# Altium schematic sheet SC8701_EVM_RE1_0624.SchDoc(SC8701_EVM_RE1_0624)
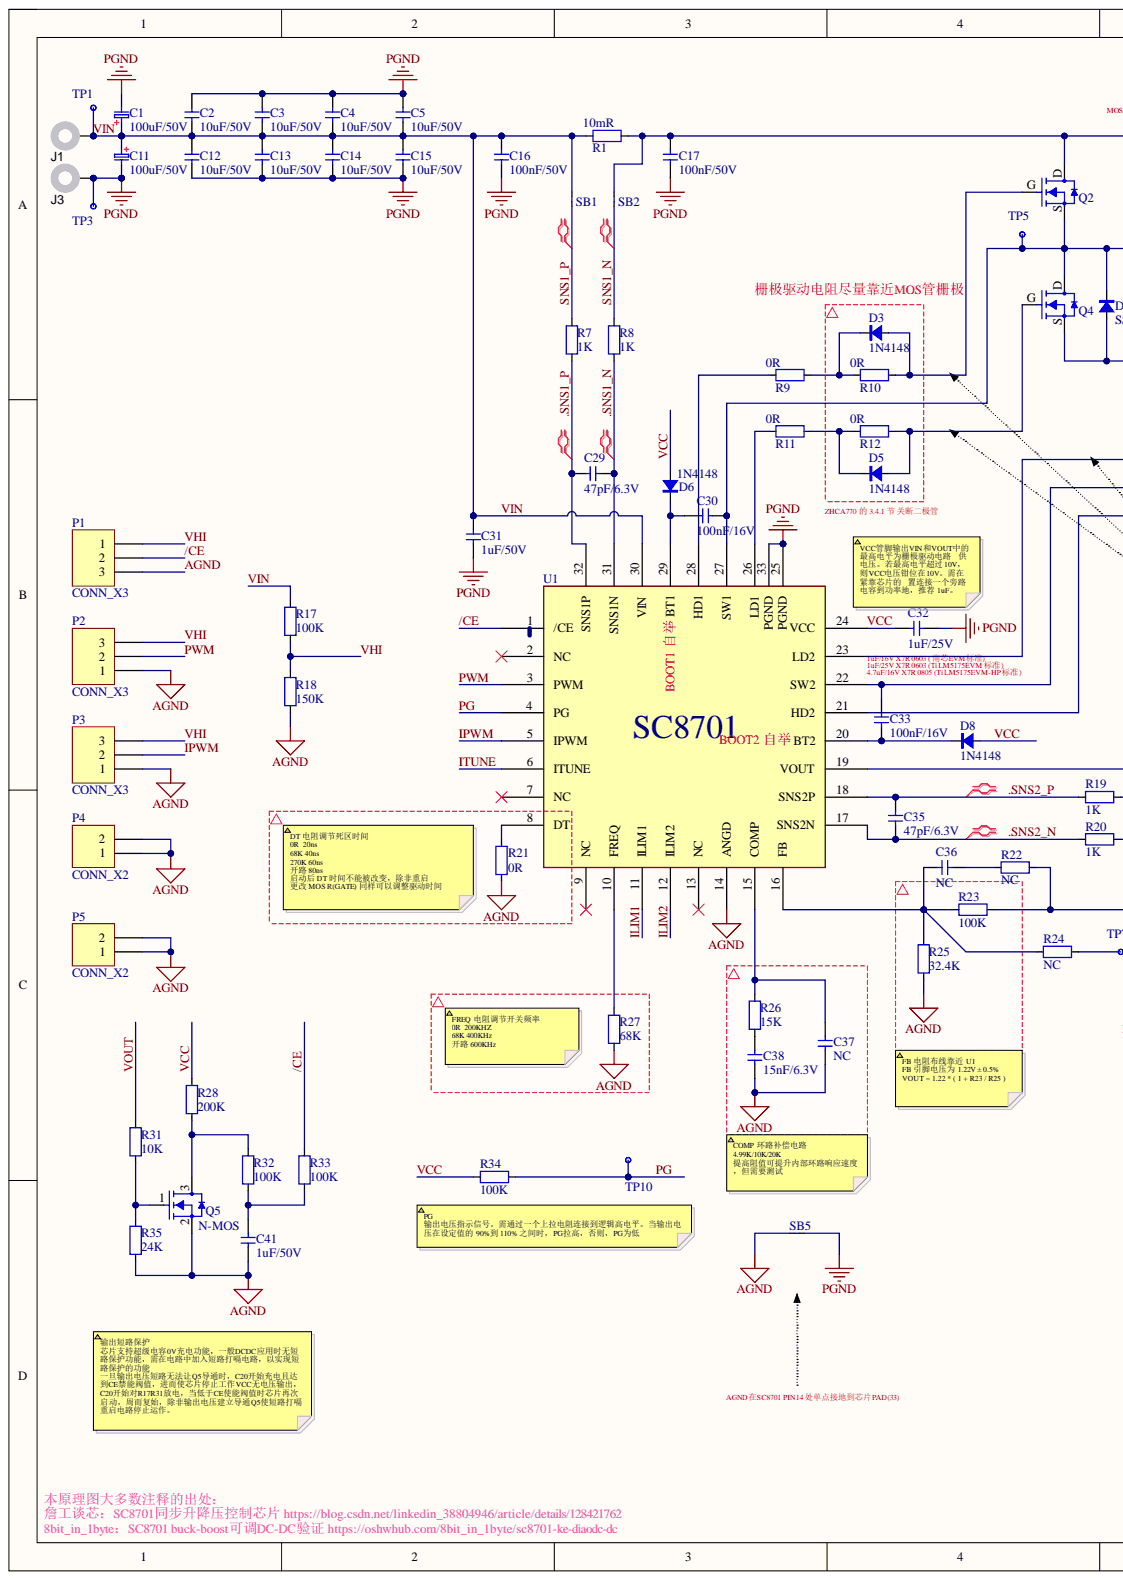
[diagram p0: SC8701_EVM_RE1_0624.SchDoc, left]
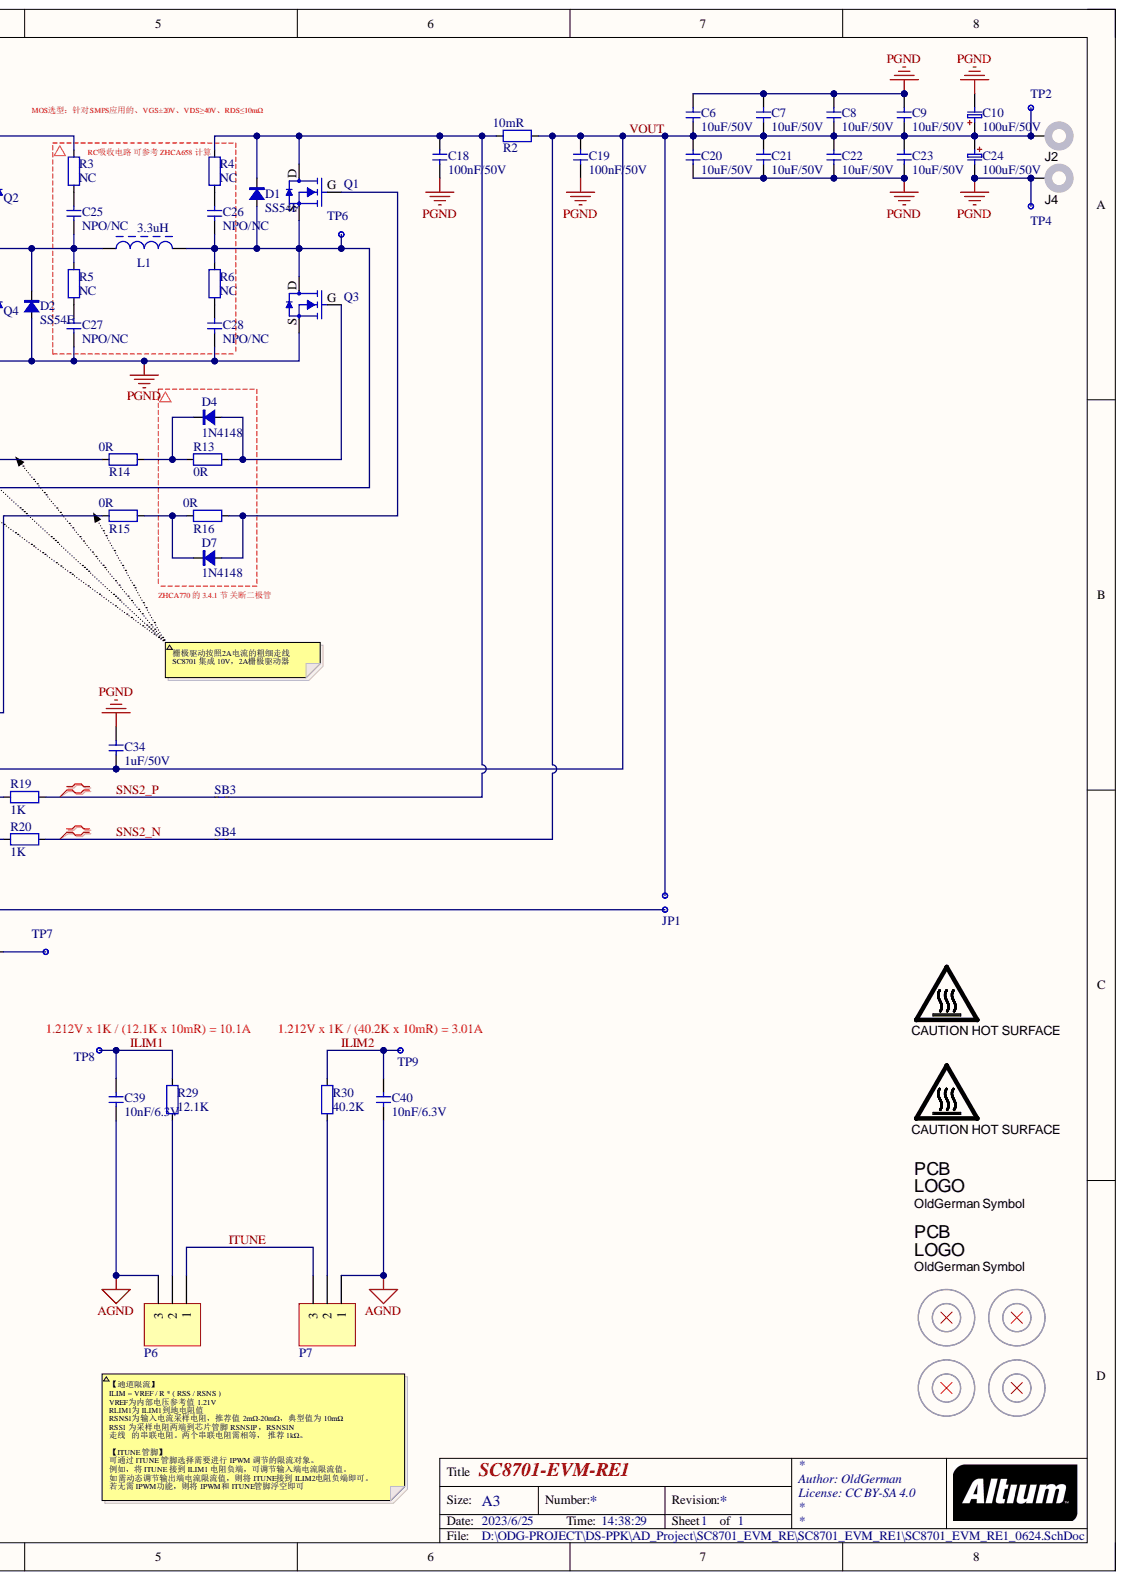
[diagram p1: SC8701_EVM_RE1_0624.SchDoc, right]

## Parts
C1  100uF/50V  [CAP OS-CON D=10.0 L=10.0 F10]
C2  10uF/50V  [C1210]
C3  10uF/50V  [C1210]
C4  10uF/50V  [C1210]
C5  10uF/50V  [C1210]
C6  10uF/50V  [C1210]
C7  10uF/50V  [C1210]
C8  10uF/50V  [C1210]
C9  10uF/50V  [C1210]
C10  100uF/50V  [CAP OS-CON D=10.0 L=10.0 F10]
C11  100uF/50V  [CAP OS-CON D=10.0 L=10.0 F10]
C12  10uF/50V  [C1210]
C13  10uF/50V  [C1210]
C14  10uF/50V  [C1210]
C15  10uF/50V  [C1210]
C16  100nF/50V  [C0603]
C17  100nF/50V  [C0603]
C18  100nF/50V  [C0603]
C19  100nF/50V  [C0603]
C20  10uF/50V  [C1210]
C21  10uF/50V  [C1210]
C22  10uF/50V  [C1210]
C23  10uF/50V  [C1210]
C24  100uF/50V  [CAP OS-CON D=10.0 L=10.0 F10]
C25  NPO/NC  [C0805]
C26  NPO/NC  [C0805]
C27  NPO/NC  [C0805]
C28  NPO/NC  [C0805]
C29  47pF/6.3V  [C0603]
C30  100nF/16V  [C0603]
C31  1uF/50V  [C0603]
C32  1uF/25V  [C0805]
C33  100nF/16V  [C0603]
C34  1uF/50V  [C0603]
C35  47pF/6.3V  [C0603]
C36  NC  [C0603]
C37  NC  [C0603]
C38  15nF/6.3V  [C0603]
C39  10nF/6.3V  [C0603]
C40  10nF/6.3V  [C0603]
C41  1uF/50V  [C0603]
D1  SS54F  [SMAF]
D2  SS54F  [SMAF]
D3  1N4148  [SOD-323]
D4  1N4148  [SOD-323]
D5  1N4148  [SOD-323]
D6  1N4148  [SOD-323]
D7  1N4148  [SOD-323]
D8  1N4148  [SOD-323]
H1  HOLE  [M3.2 D=8]  (value not drawn)
H2  HOLE  [M3.2 D=8]  (value not drawn)
H3  HOLE  [M3.2 D=8]  (value not drawn)
H4  HOLE  [M3.2 D=8]  (value not drawn)
J1  U6047  [JACK_BANANA_U6047]  (value not drawn)
J2  U6047  [JACK_BANANA_U6047]  (value not drawn)
J3  U6047  [JACK_BANANA_U6047]  (value not drawn)
J4  U6047  [JACK_BANANA_U6047]  (value not drawn)
JP1  CONN_X2_DOT  [2.54mm_Male_x2]  (value not drawn)
L1  3.3uH  [INDUCTOR 1350 12.6X13.5X5.0mm]
LOGO1  CAUTION HOT SURFACE  [IEC_HotSurface_Small]  (no BOM)
LOGO2  CAUTION HOT SURFACE  [IEC_HotSurface_Small]  (no BOM)
Logo3  OldGerman Symbol  [ODG_LOGO]  (no BOM)
Logo4  OldGerman Symbol  [ODG_LOGO]  (no BOM)
P1  CONN_X3  [2.54mm_Male_x3]
P2  CONN_X3  [2.54mm_Male_x3]
P3  CONN_X3  [2.54mm_Male_x3]
P4  CONN_X2  [2.54mm_Male_x2]
P5  CONN_X2  [2.54mm_Male_x2]
P6  CONN_X3  [2.54mm_Male_x3]  (value not drawn)
P7  CONN_X3  [2.54mm_Male_x3]  (value not drawn)
Q1  N-MOS_GDS  [SO8FL ROUND]  (value not drawn)
Q2  N-MOS_GDS  [SO8FL ROUND]  (value not drawn)
Q3  N-MOS_GDS  [SO8FL ROUND]  (value not drawn)
Q4  N-MOS_GDS  [SO8FL ROUND]  (value not drawn)
Q5  N-MOS  [INFINEON SOT-23-3]
R1  10mR  [R1206]
R2  10mR  [R1206]
R3  NC  [R0805]
R4  NC  [R0805]
R5  NC  [R0805]
R6  NC  [R0805]
R7  1K  [R0603]
R8  1K  [R0603]
R9  0R  [R0603]
R10  0R  [R0603]
R11  0R  [R0603]
R12  0R  [R0603]
R13  0R  [R0603]
R14  0R  [R0603]
R15  0R  [R0603]
R16  0R  [R0603]
R17  100K  [R0603]
R18  150K  [R0603]
R19  1K  [R0603]
R20  1K  [R0603]
R21  0R  [R0603]
R22  NC  [R0603]
R23  100K  [R0603]
R24  NC  [R0603]
R25  32.4K  [R0603]
R26  15K  [R0603]
R27  68K  [R0603]
R28  200K  [R0603]
R29  12.1K  [R0603]
R30  40.2K  [R0603]
R31  10K  [R0603]
R32  100K  [R0603]
R33  100K  [R0603]
R34  100K  [R0603]
R35  24K  [R0603]
SB1  NetTie_200um  [NetTie_200um]  (value not drawn)
SB2  NetTie_200um  [NetTie_200um]  (value not drawn)
SB3  NetTie_200um  [NetTie_200um]  (value not drawn)
SB4  NetTie_200um  [NetTie_200um]  (value not drawn)
SB5  NetTie_200um  [NetTie_200um]  (value not drawn)
TP1  CONN_X1_DOT  [2.54mm_Male_x1]  (value not drawn)
TP2  CONN_X1_DOT  [2.54mm_Male_x1]  (value not drawn)
TP3  CONN_X1_DOT  [2.54mm_Male_x1]  (value not drawn)
TP4  CONN_X1_DOT  [2.54mm_Male_x1]  (value not drawn)
TP5  CONN_X1_DOT  [2.54mm_Male_x1]  (value not drawn)
TP6  CONN_X1_DOT  [2.54mm_Male_x1]  (value not drawn)
TP7  CONN_X1_DOT  [2.54mm_Male_x1]  (value not drawn)
TP8  CONN_X1_DOT  [2.54mm_Male_x1]  (value not drawn)
TP9  CONN_X1_DOT  [2.54mm_Male_x1]  (value not drawn)
TP10  CONN_X1_DOT  [2.54mm_Male_x1]  (value not drawn)
U1  SC8701 (A)  [TQFN-32 4X4MM 0.4 VIA]  (value not drawn)

## Nets
PGND: C1.2 C2.1 C3.1 C4.1 C5.1 C6.1 C7.1 C8.1 C9.1 C10.2 C11.2 C12.1 C13.1 C14.1 C15.1 C16.1 C17.1 C18.1 C19.1 C20.1 C21.1 C22.1 C23.1 C24.2 C27.1 C28.1 C31.2 C32.2 C34.2 D2.1 J3.1 J4.1 Q3.S Q4.S SB5.2 TP3.1 TP4.1 U1.25(PGND) U1.33(PGND)
.SNS1_N: C29.2 R8.1 U1.31(SNS1N)
.SNS1_P: C29.1 R7.1 U1.32(SNS1P)
.SNS2_N: C35.2 R20.2 U1.17(SNS2N)
.SNS2_P: C35.1 R19.2 U1.18(SNS2P)
/CE: P1.2 R33.2 U1.1(/CE)
AGND: C37.1 C38.1 C39.1 C40.1 C41.2 P1.3 P2.1 P3.1 P4.1 P4.2 P5.1 P5.2 P6.3 P7.1 Q5.2 R18.1 R21.1 R25.1 R27.1 R35.1 SB5.1 U1.14(ANGD)
ILIM1: C39.2 R29.2 TP8.1 U1.11(ILIM1)
ILIM2: C40.2 R30.2 TP9.1 U1.12(ILIM2)
IPWM: P3.2 U1.5(IPWM)
ITUNE: P6.1 P7.3 U1.6(ITUNE)
PG: R34.2 TP10.1 U1.4(PG)
PWM: P2.2 U1.3(PWM)
SNS1_N: R8.2 SB2.1
SNS1_P: R7.2 SB1.1
SNS2_N: R20.1 SB4.1
SNS2_P: R19.1 SB3.1
VCC: C32.1 D6.1 D8.1 R28.2 R34.1 U1.24(VCC)
VHI: P1.1 P2.3 P3.3 R17.1 R18.2
VIN: C1.1 C2.2 C3.2 C4.2 C5.2 C11.1 C12.2 C13.2 C14.2 C15.2 C16.2 C31.1 J1.1 R1.1 R17.2 SB1.2 TP1.1 U1.30(VIN)
VOUT: C6.2 C7.2 C8.2 C9.2 C10.1 C19.2 C20.2 C21.2 C22.2 C23.2 C24.1 C34.1 J2.1 JP1.1 R2.2 R31.2 SB4.2 TP2.1 U1.19(VOUT)
NetC17_2: C17.2 Q2.D R1.2 R3.2 SB2.2
NetC18_2: C18.2 D1.2 Q1.D R2.1 R4.2 SB3.2
NetC25_1: C25.1 C30.2 D2.2 L1.1 Q2.S Q4.D R5.2 TP5.1 U1.27(SW1)
NetC25_2: C25.2 R3.1
NetC26_1: C26.1 C33.1 D1.1 L1.2 Q1.S Q3.D R6.2 TP6.1 U1.22(SW2)
NetC26_2: C26.2 R4.1
NetC27_2: C27.2 R5.1
NetC28_2: C28.2 R6.1
NetC30_1: C30.1 D6.2 U1.29(BT1)
NetC33_2: C33.2 D8.2 U1.20(BT2)
NetC36_1: C36.1 R23.1 R24.2 R25.2 U1.16(FB)
NetC36_2: C36.2 R22.2
NetC37_2: C37.2 R26.2 U1.15(COMP)
NetC38_2: C38.2 R26.1
NetC41_1: C41.1 R32.1 R33.1
NetD3_1: D3.1 Q2.G R10.2
NetD3_2: D3.2 R9.2 R10.1
NetD4_1: D4.1 Q3.G R13.2
NetD4_2: D4.2 R13.1 R14.2
NetD5_1: D5.1 Q4.G R12.2
NetD5_2: D5.2 R11.2 R12.1
NetD7_1: D7.1 Q1.G R16.2
NetD7_2: D7.2 R15.2 R16.1
NetJP1_2: JP1.2 R22.1 R23.2
NetP6_2: P6.2 R29.1
NetP7_2: P7.2 R30.1
NetQ5_1: Q5.1 R31.1 R35.2
NetQ5_3: Q5.3 R28.1 R32.2
NetR9_1: R9.1 U1.28(HD1)
NetR11_1: R11.1 U1.26(LD1)
NetR14_1: R14.1 U1.23(LD2)
NetR15_1: R15.1 U1.21(HD2)
NetR21_2: R21.2 U1.8(DT)
NetR24_1: R24.1 TP7.1
NetR27_2: R27.2 U1.10(FREQ)

Not connected: H1 1; H2 1; H3 1; H4 1; U1 2(NC) 7(NC) 9(NC) 13(NC)

## Library data of the parts
C1 C10 C11 C24 = CAP_Polar_Aluminum: Capacitor; Component Kind: Standard; Library Name: ODG_AD_Lib.IntLib
C2-C9 C12-C23 C25-C41 = CAP: Capacitor; Component Kind: Standard; Library Name: ODG_AD_Lib.IntLib
D1-D8 = Diode: 二极管; Component Kind: Standard; Library Name: ODG_AD_Lib.IntLib
J1-J4 = JACK_BANANA: Banana Jack; Manufacturer: Keystone; PartNumber: 575-8; CompType: Connector; U/M: EA; Component Kind: Standard; ComponentLink1Description: Datasheet Link; ComponentLink1URL: http://www.keyelco.com/pdfs/M55p95.pdf; LatestRevisionDate: 2015-08-25; MountType: TH; PackageReference: Keystone575-8; RoHS: Y; Supplier 1: Digi-Key; Supplier Part Number 1: 36-575-8-ND; Library Name: ODG_AD_Lib.IntLib; Publisher: Texas Instruments
L1 = Inductor_power: 135 功率电感 额定电流15A; Component Kind: Standard; Library Name: ODG_AD_Lib.IntLib
LOGO1 LOGO2 = IEC_HotSurface: IEC 61010-1 Hot Surface Warning; SymbolCreator: a0412235; LatestRevisionDate: 2014-11-20; Published: 2009-03-03; SymbolVersion: 2011-08-22; Library Name: ODG_AD_Lib.IntLib; Publisher: Texas Instruments
Logo3 Logo4 = OldGeman: SymbolCreator: a0412235; Description: OldGerman Symbol; LatestRevisionDate: 2014-11-20; Library Name: ODG_AD_Lib.IntLib; Published: 2009-03-03; SymbolVersion: 2011-08-22; Publisher: Texas Instruments
Q5 = N-MOS_123: Component Kind: Standard; Description: N-MOS; Library Name: ODG_AD_Lib.IntLib
R1-R35 = RES: IEC Standard; Component Kind: Standard; Library Name: ODG_AD_Lib.IntLib
SB1-SB5 = NetTie 0.2mm: Net Tie; Artikkelnr Noca: N.A.; Pad Count: 2; Component Class: Connectors; Component Kind: Net Tie; ComponentLink1Description: Datasheet; ComponentLink2Description: Supplier link; Footprint Doc: NetTie; LatestRevisionDate: 2019-10-07; Library Name: pca63100.IntLib; Part Number: NetTie 0.2mm; Part number IPS: N.A.; PartId: 100500654; Pin Count: 2; Published: 2019-10-07; Value: NetTie_200um; Publisher: Nordic Semiconductor
U1 = SC8701 (A): 高效率, 同步, 4 管升降压控制器; Component Kind: Standard; Library Name: ODG_AD_Lib.IntLib
H1-H4 = HOLE: Component Kind: Standard; Library Name: ODG_AD_Lib.IntLib
JP1 = CONN_X2_DOT: Component Kind: Standard; Library Name: ODG_AD_Lib.IntLib
P1-P3 P6 P7 = CONN_X3: Component Kind: Standard; Library Name: ODG_AD_Lib.IntLib
P4 P5 = CONN_X2: Component Kind: Standard; Library Name: ODG_AD_Lib.IntLib
Q1-Q4 = N-MOS_GDS: Component Kind: Standard; Library Name: ODG_AD_Lib.IntLib
TP1-TP10 = CONN_X1_DOT: Component Kind: Standard; Library Name: ODG_AD_Lib.IntLib
